# Altium schematic sheet km03_8600u.SchDoc
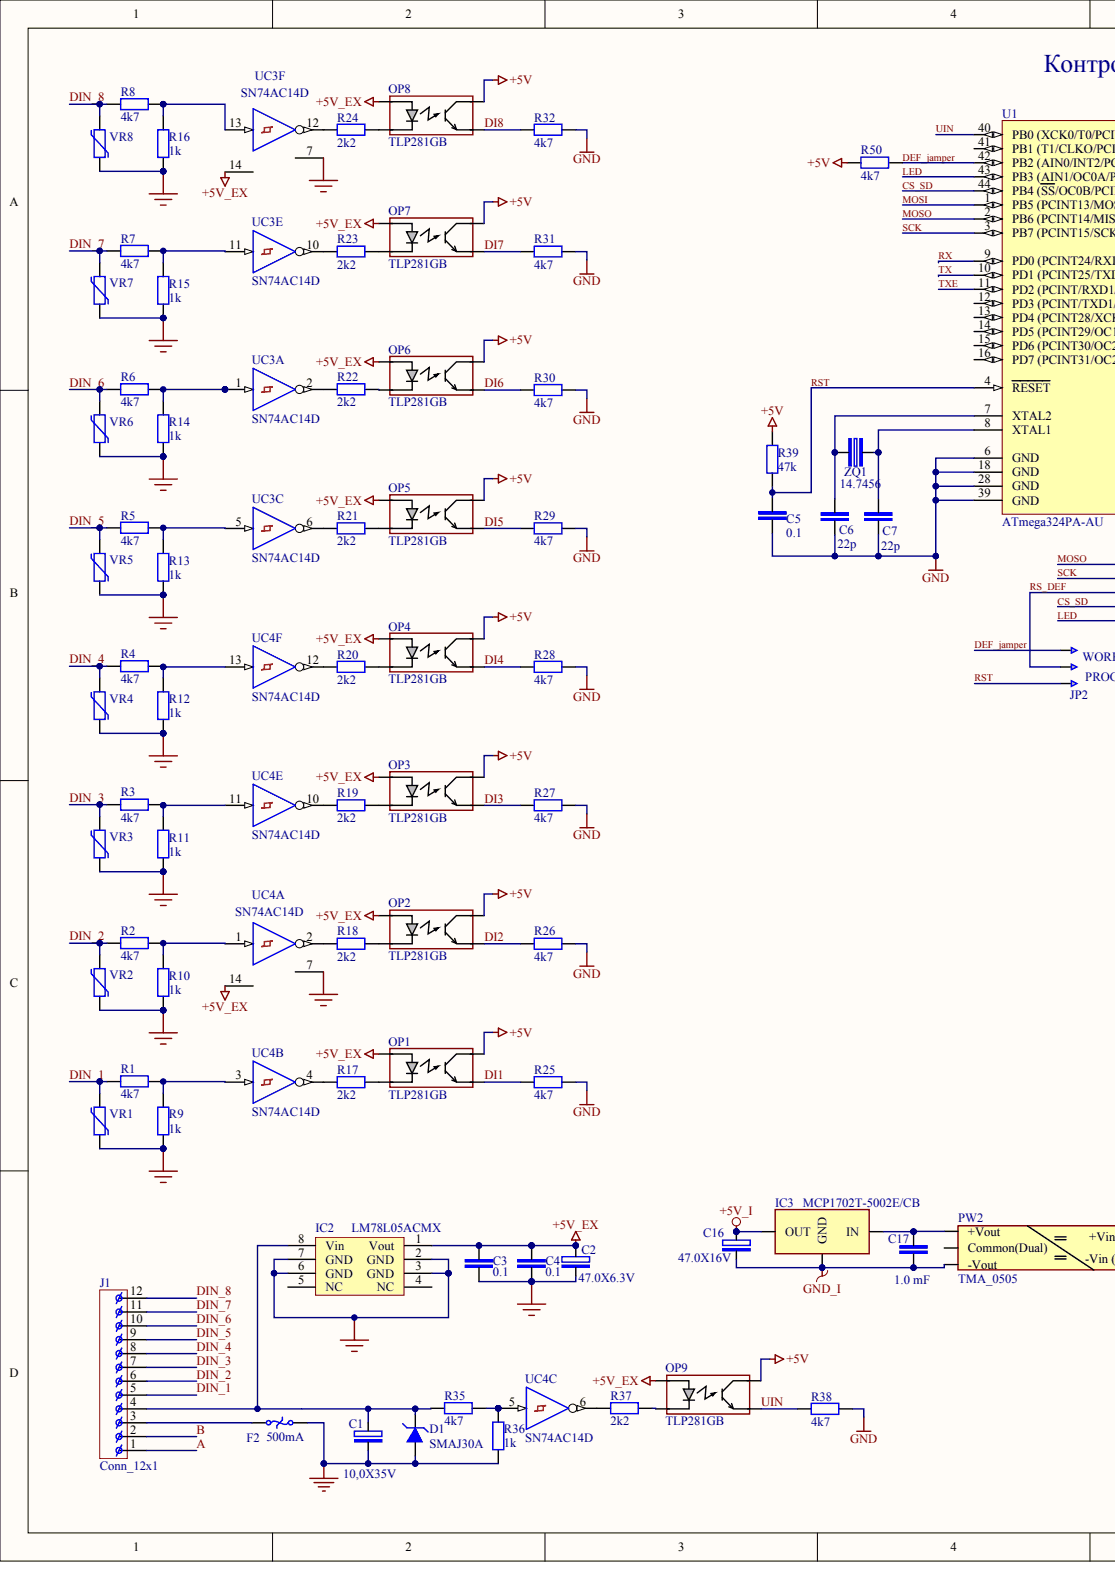
[diagram p0: km03_8600u.SchDoc, left]
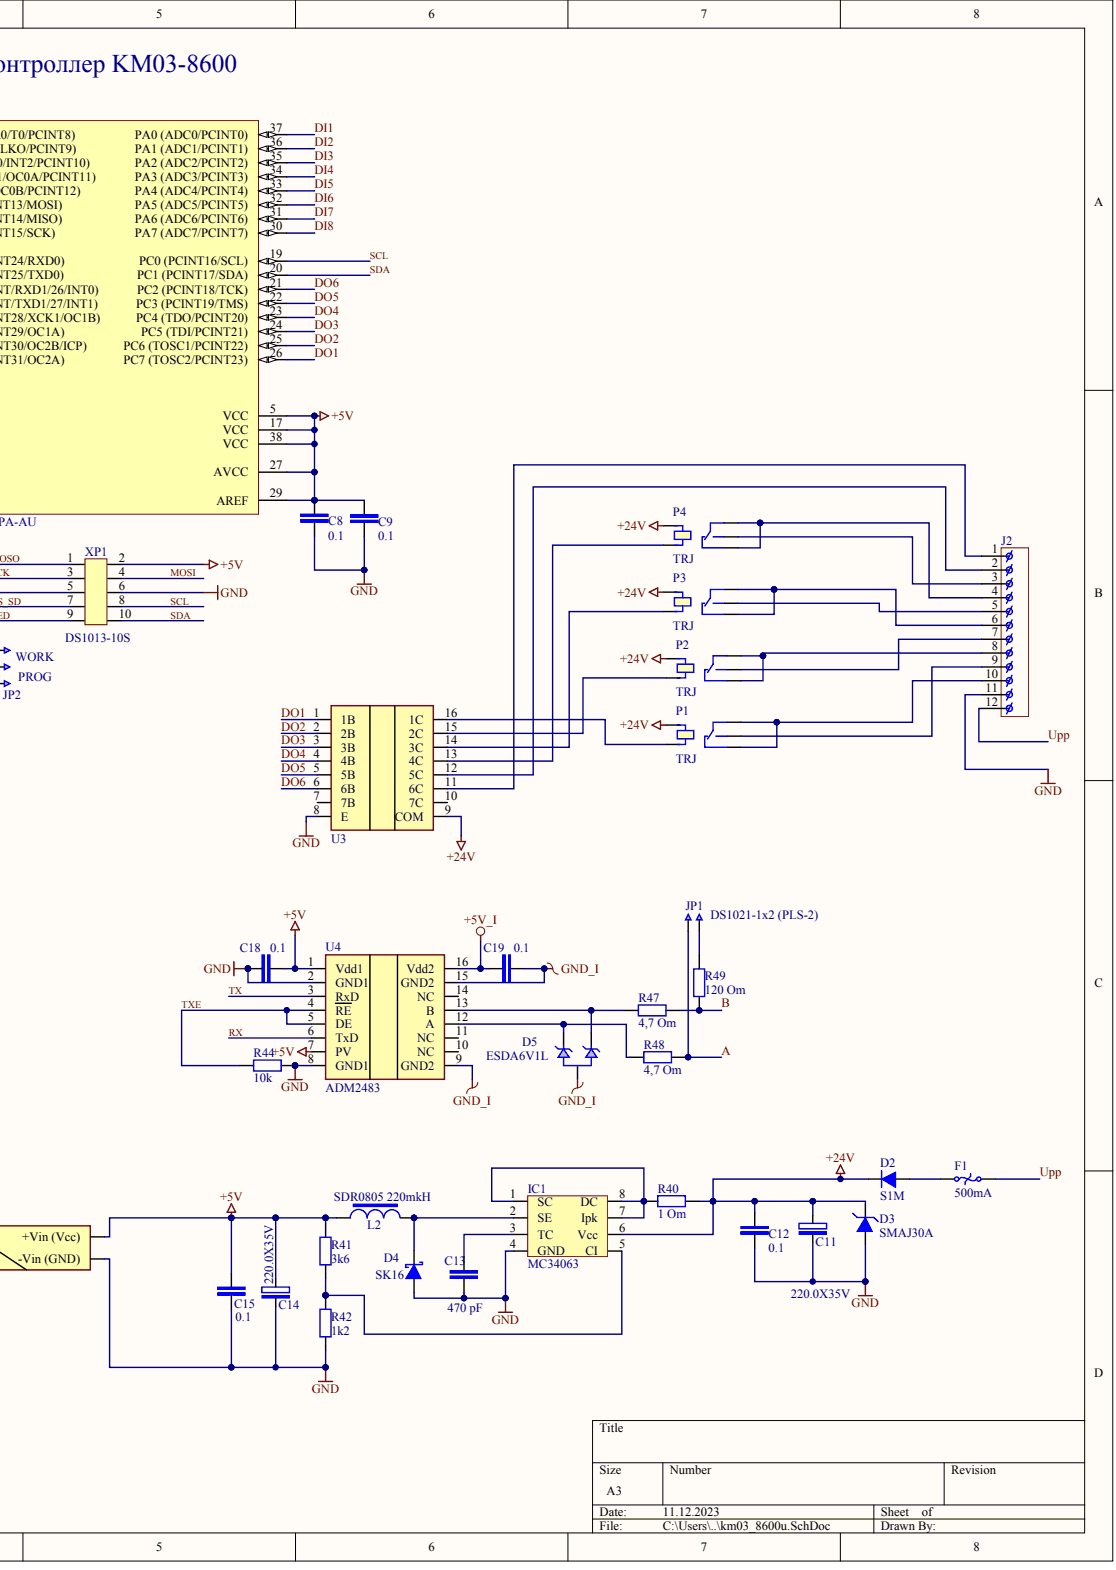
[diagram p1: km03_8600u.SchDoc, right]

## Parts
C1  10,0X35V
C2  47.0X6.3V
C3  0.1
C4  0.1
C5  0.1
C6  22p
C7  22p
C8  0.1
C9  0.1
C11  220.0X35V
C12  0.1
C13  470 pF
C14  220.0X35V
C15  0.1
C16  47.0X16V
C17  1.0 mF
C18  0.1
C19  0.1
D1  SMAJ30A
D2  S1M
D3  SMAJ30A
D4  SK16
D5  ESDA6V1L
F1  500mA
F2  500mA
IC1  MC34063
IC2  LM78L05ACMX
IC3  MCP1702T-5002E/CB
J1  Conn_12x1
J2  Conn_12x1
JP1  DS1021-1x2 (PLS-2)
JP2  JP_3X2.5  (value not drawn)
L2  SDR0805 220mkH
OP1  TLP281GB
OP2  TLP281GB
OP3  TLP281GB
OP4  TLP281GB
OP5  TLP281GB
OP6  TLP281GB
OP7  TLP281GB
OP8  TLP281GB
OP9  TLP281GB
P1  TRJ
P2  TRJ
P3  TRJ
P4  TRJ
PW2  TMA_0505
R1  4k7
R2  4k7
R3  4k7
R4  4k7
R5  4k7
R6  4k7
R7  4k7
R8  4k7
R9  1k
R10  1k
R11  1k
R12  1k
R13  1k
R14  1k
R15  1k
R16  1k
R17  2k2
R18  2k2
R19  2k2
R20  2k2
R21  2k2
R22  2k2
R23  2k2
R24  2k2
R25  4k7
R26  4k7
R27  4k7
R28  4k7
R29  4k7
R30  4k7
R31  4k7
R32  4k7
R35  4k7
R36  1k
R37  2k2
R38  4k7
R39  47k
R40  1 Om
R41  3k6
R42  1k2
R44  10k
R47  4,7 Om
R48  4,7 Om
R49  120 Om
R50  4k7
U1  ATmega324PA-AU
U3  ULN2003A  (value not drawn)
U4  ADM2483
UC3  SN74AC14D  (parts A,C,E,F)
UC4  SN74AC14D  (parts A,B,C,E,F)
VR1  CN1206K25G  (value not drawn)
VR2  CN1206K25G  (value not drawn)
VR3  CN1206K25G  (value not drawn)
VR4  CN1206K25G  (value not drawn)
VR5  CN1206K25G  (value not drawn)
VR6  CN1206K25G  (value not drawn)
VR7  CN1206K25G  (value not drawn)
VR8  CN1206K25G  (value not drawn)
XP1  DS1013-10S
ZQ1  14.7456

## Nets
+5V: C8.1 C9.1 C14.1 C15.1 C18.1 L2.2 OP1.4 OP2.4 OP3.4 OP4.4 OP5.4 OP6.4 OP7.4 OP8.4 OP9.4 PW2.1(+Vin_(Vcc)) R39.2 R41.2 R50.1 U1.5(VCC) U1.17(VCC) U1.27(AVCC) U1.29(AREF) U1.38(VCC) U4.1(Vdd1) U4.7(PV) XP1.2
+5V_EX: C2.1 C3.1 C4.1 IC2.1(Vout) OP1.1 OP2.1 OP3.1 OP4.1 OP5.1 OP6.1 OP7.1 OP8.1 OP9.1 UC3.14 UC4.14
+5V_I: C16.1 C19.1 IC3.2 U4.16(Vdd2)
+24V: C11.1 C12.1 D2.2 D3.2 IC1.6(Vcc) P1.1 P2.1 P3.1 P4.1 R40.2 U3.9(COM)
GND: C5.2 C6.2 C7.2 C8.2 C9.2 C11.2 C12.2 C13.2 C14.2 C15.2 C18.2 D4.1 IC1.4(GND) J2.11 PW2.2(-Vin_(GND)) R25.2 R26.2 R27.2 R28.2 R29.2 R30.2 R31.2 R32.2 R38.2 R42.1 R44.1 U1.6(GND) U1.18(GND) U1.28(GND) U1.39(GND) U3.8(E) U4.2(GND1) U4.8(GND1) UC3.7 UC4.7 XP1.6
GND_EX: C1.2 C2.2 C3.2 C4.2 D1.1 F2.2 IC2.2(GND) IC2.3(GND) IC2.6(GND) IC2.7(GND) R9.2 R10.2 R11.2 R12.2 R13.2 R14.2 R15.2 R16.2 R36.2 UC3.7 UC4.7 VR1.1 VR2.1 VR3.1 VR4.1 VR5.1 VR6.1 VR7.1 VR8.1
GND_I: C16.2 C17.2 C19.2 D5.3 IC3.1 PW2.3(-Vout) U4.9(GND2) U4.15(GND2)
VCC: UC3.14 UC4.14
A: J1.1 JP1.1 R48.1
B: J1.2 R47.1 R49.1
CS_SD: U1.44(PB4_(SS/OC0B/PCINT12)) XP1.7
DEF_JAMPER: JP2.1 R50.2 U1.42(PB2_(AIN0/INT2/PCINT10))
DI1: OP1.3 R25.1 U1.37(PA0_(ADC0/PCINT0))
DI2: OP2.3 R26.1 U1.36(PA1_(ADC1/PCINT1))
DI3: OP3.3 R27.1 U1.35(PA2_(ADC2/PCINT2))
DI4: OP4.3 R28.1 U1.34(PA3_(ADC3/PCINT3))
DI5: OP5.3 R29.1 U1.33(PA4_(ADC4/PCINT4))
DI6: OP6.3 R30.1 U1.32(PA5_(ADC5/PCINT5))
DI7: OP7.3 R31.1 U1.31(PA6_(ADC6/PCINT6))
DI8: OP8.3 R32.1 U1.30(PA7_(ADC7/PCINT7))
DIN_1: J1.5 R1.1 VR1.2
DIN_2: J1.6 R2.1 VR2.2
DIN_3: J1.7 R3.1 VR3.2
DIN_4: J1.8 R4.1 VR4.2
DIN_5: J1.9 R5.1 VR5.2
DIN_6: J1.10 R6.1 VR6.2
DIN_7: J1.11 R7.1 VR7.2
DIN_8: J1.12 R8.1 VR8.2
DO1: U1.26(PC7_(TOSC2/PCINT23)) U3.1(1B)
DO2: U1.25(PC6_(TOSC1/PCINT22)) U3.2(2B)
DO3: U1.24(PC5_(TDI/PCINT21)) U3.3(3B)
DO4: U1.23(PC4_(TDO/PCINT20)) U3.4(4B)
DO5: U1.22(PC3_(PCINT19/TMS)) U3.5(5B)
DO6: U1.21(PC2_(PCINT18/TCK)) U3.6(6B)
LED: U1.43(PB3_(AIN1/OC0A/PCINT11)) XP1.9
MOSI: U1.1(PB5_(PCINT13/MOSI)) XP1.4
MOSO: U1.2(PB6_(PCINT14/MISO)) XP1.1
RS_DEF: JP2.2 XP1.5
RST: C5.1 JP2.3 R39.1 U1.4(RESET)
RX: U1.9(PD0_(PCINT24/RXD0)) U4.6(TxD)
SCK: U1.3(PB7_(PCINT15/SCK)) XP1.3
SCL: U1.19(PC0_(PCINT16/SCL)) XP1.8
SDA: U1.20(PC1_(PCINT17/SDA)) XP1.10
TX: U1.10(PD1_(PCINT25/TXD0)) U4.3(RxD)
TXE: R44.2 U1.11(PD2_(PCINT/RXD1/26/INT0)) U4.4(RE) U4.5(DE)
UIN: OP9.3 R38.1 U1.40(PB0_(XCK0/T0/PCINT8))
UPP: F1.2 J2.12
NetC1_1: C1.1 D1.2 IC2.8(Vin) J1.4 R35.1
NetC6_1: C6.1 U1.7(XTAL2) ZQ1.1
NetC7_1: C7.1 U1.8(XTAL1) ZQ1.2
NetC13_1: C13.1 IC1.3(TC)
NetC17_1: C17.1 IC3.3 PW2.5(+Vout)
NetD2_1: D2.1 F1.1
NetD4_2: D4.2 IC1.2(SE) L2.1
NetD5_1: D5.1 R47.2 U4.13(B)
NetD5_2: D5.2 R48.2 U4.12(A)
NetF2_1: F2.1 J1.3
NetIC1_1: IC1.1(SC) IC1.7(Ipk) IC1.8(DC) R40.1
NetIC1_5: IC1.5(CI) R41.1 R42.2
NetJ2_1: J2.1 U3.11(6C)
NetJ2_2: J2.2 U3.12(5C)
NetJ2_3: J2.3 P4.3
NetJ2_4: J2.4 P4.2 P4.4
NetJ2_5: J2.5 P3.3
NetJ2_6: J2.6 P3.2 P3.4
NetJ2_7: J2.7 P2.3
NetJ2_8: J2.8 P2.2 P2.4
NetJ2_9: J2.9 P1.3
NetJ2_10: J2.10 P1.2 P1.4
NetJP1_2: JP1.2 R49.2
NetOP1_2: OP1.2 R17.2
NetOP2_2: OP2.2 R18.2
NetOP3_2: OP3.2 R19.2
NetOP4_2: OP4.2 R20.2
NetOP5_2: OP5.2 R21.2
NetOP6_2: OP6.2 R22.2
NetOP7_2: OP7.2 R23.2
NetOP8_2: OP8.2 R24.2
NetOP9_2: OP9.2 R37.2
NetP1_5: P1.5 U3.16(1C)
NetP2_5: P2.5 U3.15(2C)
NetP3_5: P3.5 U3.14(3C)
NetP4_5: P4.5 U3.13(4C)
NetR1_2: R1.2 R9.1 UC4.3
NetR2_2: R2.2 R10.1 UC4.1
NetR3_2: R3.2 R11.1 UC4.11
NetR4_2: R4.2 R12.1 UC4.13
NetR5_2: R5.2 R13.1 UC3.5
NetR6_2: R6.2 R14.1 UC3.1
NetR7_2: R7.2 R15.1 UC3.11
NetR8_2: R8.2 R16.1 UC3.13
NetR17_1: R17.1 UC4.4
NetR18_1: R18.1 UC4.2
NetR19_1: R19.1 UC4.10
NetR20_1: R20.1 UC4.12
NetR21_1: R21.1 UC3.6
NetR22_1: R22.1 UC3.2
NetR23_1: R23.1 UC3.10
NetR24_1: R24.1 UC3.12
NetR35_2: R35.2 R36.1 UC4.5
NetR37_1: R37.1 UC4.6

Not connected: D3 1; IC2 4(NC) 5(NC); PW2 4(Common(Dual)); U1 12(PD3_(PCINT/TXD1/27/INT1)) 13(PD4_(PCINT28/XCK1/OC1B)) 14(PD5_(PCINT29/OC1A)) 15(PD6_(PCINT30/OC2B/ICP)) 16(PD7_(PCINT31/OC2A)) 41(PB1_(T1/CLKO/PCINT9)); U3 7(7B) 10(7C); U4 10(NC) 11(NC) 14(NC)

## Library data of the parts
C1-C9 C11-C19 D1-D5 F1 F2 IC1-IC3 J1 J2 JP1 JP2 L2 OP1-OP9 P1-P4 PW2 R1-R32 R35-R42 R44 R47-R50 U1 U3 U4 UC3 UC4 VR1-VR8 XP1 ZQ1 = (no symbol name)
  U1: Published: 1-Apr-2010; DatasheetVersion: revA, Jan-2010; PackageVersion: rev.B, Oct-2001; PackageReference: 44A; PackageDescription: 44-Pin Plastic Thin Profile Quad Flat Pack, 10 x 10 x 1.0 mm Body, 0.8 mm Pitch (TQFP); Supplier 1: Digi-Key; Supplier Part Number 1: ATMEGA644PA-AU-ND; Supplier 2: Mouser; Supplier Part Number 2: 556-ATMEGA644PA-AU; ComponentLink1Description: Manufacturer Link; ComponentLink1URL: http://www.atmel.com/products/avr/default.asp?; ComponentLink2Description: ATmega164A/164PA/324A/324PA/644A/644PA/1284/1284P Datasheet; ComponentLink2URL: http://www.atmel.com/dyn/resources/prod_documents/doc8272.pdf; ComponentLink3Description: ATmega164A/164PA/324A/324PA/644A/644PA/1284/1284P Summary; ComponentLink3URL: http://www.atmel.com/dyn/resources/prod_documents/8272S.pdf; ComponentLink4Description: Atmel.com Home; ComponentLink4URL: http://www.atmel.com
  UC3 UC4: Published: 8-Jun-2000; LatestRevisionDate: 13-Apr-2006; LatestRevisionNote: IPC-7351 Footprint Added.; DatasheetVersion: Feb-1998; PackageVersion: Jan-1998; PackageReference: D014; PackageDescription: 14-Pin Small Outline Integrated Circuit 1.27 mm Pitch; Code_IPC: SOIC127P600-14; ComponentLink1Description: Manufacturer Link; ComponentLink1URL: http://www.ti.com/; ComponentLink2Description: Datasheet; ComponentLink2URL: http://www-s.ti.com/sc/ds/sn74ac14.pdf; ComponentLink3Description: Packaging Specification; ComponentLink3URL: http://focus.ti.com/lit/ml/mpds177/mpds177.pdf
  U1 UC3 UC4: Publisher: Altium Limited
